# Altium schematic sheet CentralUnit_Schematic.SchDoc(CentralUnit_Schematic)
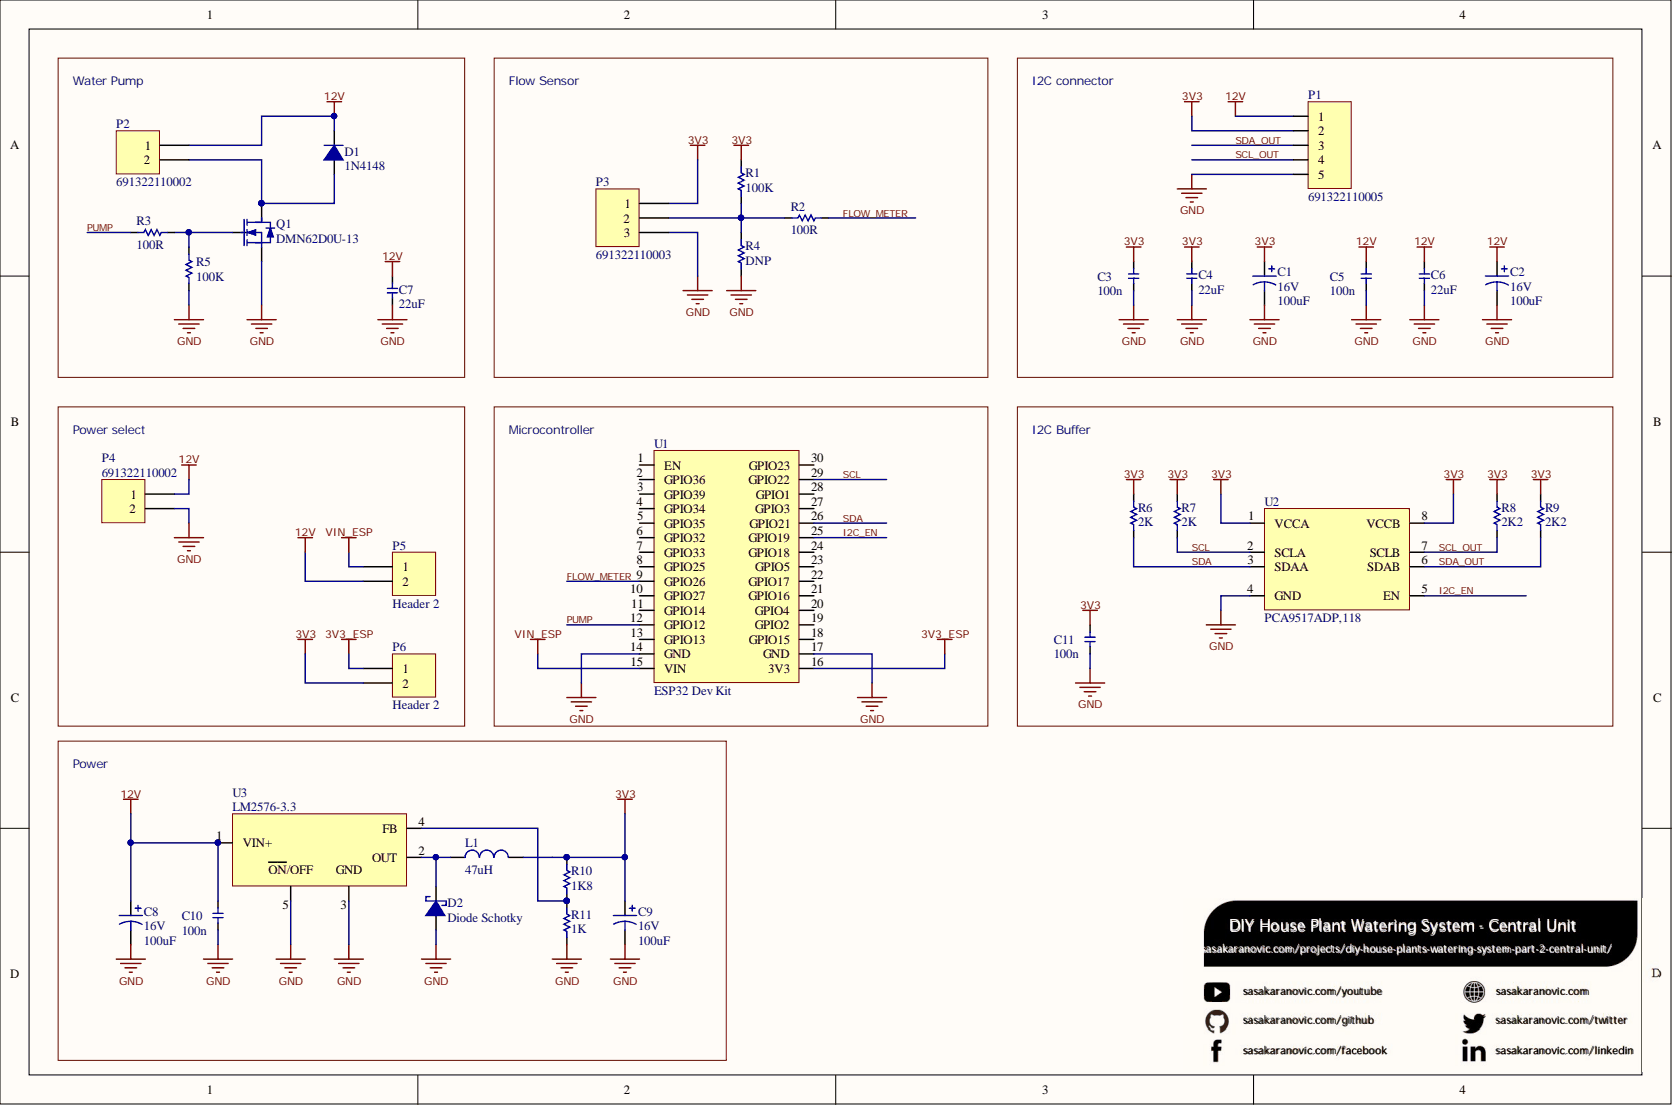
[diagram p0: CentralUnit_Schematic.SchDoc]

## Parts
C1  16V  value 100uF  [Electrolytic Cap 2.54mm]
C2  16V  value 100uF  [Electrolytic Cap 2.54mm]
C3  value 100n  [0603 - CAP HS]  (hidden comment: Cap)
C4  value 22uF  [0603 - CAP HS]  (hidden comment: Cap)
C5  value 100n  [0603 - CAP HS]  (hidden comment: Cap)
C6  value 22uF  [0603 - CAP HS]  (hidden comment: Cap)
C7  value 22uF  [0603 - CAP HS]  (hidden comment: Cap)
C8  16V  value 100uF  [Electrolytic Cap 2.54mm]
C9  16V  value 100uF  [Electrolytic Cap 2.54mm]
C10  value 100n  [0603 - CAP HS]  (hidden comment: Cap)
C11  value 100n  [0603 - CAP HS]  (hidden comment: Cap)
D1  1N4148  [SOD123F]
D2  Diode Schotky  [1206 Polarized]
L1  value 47uH  [IND_TH_SMD]  (hidden comment: Inductor)
P1  691322110005  [TERMINAL_5POS_90DEG_2PART]
P2  691322110002  [TERMINAL_2POS_90DEG_2PART]
P3  691322110003  [TERMINAL_3POS_90DEG_2PART]
P4  691322110002  [TERMINAL_2POS_90DEG_2PART]
P5  Header 2  [Header 2x1]
P6  Header 2  [Header 2x1]
Q1  DMN62D0U-13  [SOT90P240X100-3N]
R1  value 100K  [0603 - HS]  (hidden comment: Res)
R2  value 100R  [0603 - HS]  (hidden comment: Res)
R3  value 100R  [0603 - HS]  (hidden comment: Res)
R4  value DNP  [0603 - HS]  (hidden comment: Res)  (no BOM)
R5  value 100K  [0603 - HS]  (hidden comment: Res)
R6  value 2K  [0603 - HS]  (hidden comment: Res)
R7  value 2K  [0603 - HS]  (hidden comment: Res)
R8  value 2K2  [0603 - HS]  (hidden comment: Res)
R9  value 2K2  [0603 - HS]  (hidden comment: Res)
R10  value 1K8  [0603 - HS]  (hidden comment: Res)
R11  value 1K  [0603 - HS]  (hidden comment: Res)
U1  ESP32 Dev Kit  [ESP32-DevModule]
U2  PCA9517ADP,118  [MSOP8-3mm]
U3  LM2576-3.3  [TO263-5]

## Nets
3V3: C1.1 C3.2 C4.2 C9.1 C11.2 L1.2 P1.2 P3.1 P6.2 R1.2 R6.2 R7.2 R8.2 R9.2 R10.1 U2.1(VCCA) U2.8(VCCB)
3V3_ESP: P6.1 U1.16(3V3)
12V: C2.1 C5.2 C6.2 C7.2 C8.1 C10.2 D1.K P1.1 P2.1 P4.1 P5.2 U3.1(VIN+)
GND: C1.2 C2.2 C3.1 C4.1 C5.1 C6.1 C7.1 C8.2 C9.2 C10.1 C11.1 D2.A P1.5 P3.3 P4.2 Q1.2 R4.1 R5.1 R11.2 U1.14(GND) U1.17(GND) U2.4(GND) U3.3 U3.5
VIN_ESP: P5.1 U1.15(VIN)
FLOW_METER: R2.2 U1.9(GPIO26)
I2C_EN: U1.25(GPIO19) U2.5(EN)
PUMP: R3.1 U1.12(GPIO12)
SCL: R7.1 U1.29(GPIO22) U2.2(SCLA)
SCL_OUT: P1.4 R8.1 U2.7(SCLB)
SDA: R6.1 U1.26(GPIO21) U2.3(SDAA)
SDA_OUT: P1.3 R9.1 U2.6(SDAB)
NetD1_A: D1.A P2.2 Q1.3
NetD2_K: D2.K L1.1 U3.2(OUT)
NetP3_2: P3.2 R1.1 R2.1 R4.2
NetQ1_1: Q1.1 R3.2 R5.2
NetR10_2: R10.2 R11.1 U3.4(FB)

Not connected: U1 1(EN) 2(GPIO36) 3(GPIO39) 4(GPIO34) 5(GPIO35) 6(GPIO32) 7(GPIO33) 8(GPIO25) 10(GPIO27) 11(GPIO14) 13(GPIO13) 18(GPIO15) 19(GPIO2) 20(GPIO4) 21(GPIO16) 22(GPIO17) 23(GPIO5) 24(GPIO18) 27(GPIO3) 28(GPIO1) 30(GPIO23)

## Library data of the parts
C1 C2 C8 C9 = Cap Electrolytic: Polarized Capacitor (Axial); Manufacturer Part Number: UKT1V101MED1TD; Manufacturer: Nichicon; Library Name: Schematic_Misc.SchLib; Distributor Part Number: 493-10673-1-ND; Distributor: DigiKey; Component Kind: Standard
C3 C5 C10 C11 = Cap: CAP CER 0.1UF 50V X7R 0603; Manufacturer Part Number: CL10B104KB8NNNL; Manufacturer: Samsung Electro-Mechanics; Simulation: CAP; Signal Integrity: Cap; Distributor Part Number: 1276-CL10B104KB8NNNLCT-ND; Distributor: DigiKey; Component Kind: Standard; Library Name: Moji Schematici.SchLib
C4 C6 C7 = Cap: Capacitor; Manufacturer Part Number: UVZ1J220MDD1TD; Manufacturer: Nichicon; Simulation: CAP; Signal Integrity: Cap; Distributor Part Number: 493-13481-1-ND; Distributor: DigiKey; Component Kind: Standard; Library Name: Moji Schematici.SchLib
D1 = Diode: DIODE GEN PURP 100V 300MA SOD123; Manufacturer Part Number: 1N4148W-7-F; Manufacturer: Diodes Incorporated; Distributor Part Number: 1N4148W-FDICT-ND; Distributor: DigiKey; Component Kind: Standard; Library Name: Moji Schematici (1).SchLib
D2 = Diode Schotky: Manufacturer Part Number: SD1206S040S1R0; Manufacturer: AVX Corporation; Library Name: Schematic_Misc.SchLib; Distributor Part Number: 478-7805-1-ND; Distributor: DigiKey; Component Kind: Standard
L1 = Inductor: Shielded - Inductor 200mOhm Nonstandard; Manufacturer Part Number: TYS6045470M-10; Manufacturer: Laird-Signal Integrity Products; Simulation: INDUCTOR; Signal Integrity: Inductor; Library Name: Schematic_Misc.SchLib; Distributor Part Number: 240-2745-2-ND; Distributor: DigiKey; Component Kind: Standard
P1 = Terminal 5_691322110005: TERM BLOCK HDR 5POS 90DEG 3.5MM; Manufacturer: Würth Elektronik; Manufacturer Part Number: 691322110005; Library Name: Schematic_Misc.SchLib; Distributor Part Number: 732-2772-ND; Distributor: DigiKey; Component Kind: Standard
P2 P4 = Terminal 2_691322110002: 2 Position Terminal Block Header, Male Pins, Shrouded (4 Side) 0.138" (3.50mm) 90°, Right Angle - Through Hole; Manufacturer: Würth Elektronik; Manufacturer Part Number: 691322110002; Library Name: Schematic_Misc.SchLib; Distributor Part Number: 732-2769-ND; Distributor: DigiKey; Component Kind: Standard
P3 = Terminal 3_691322110003_1: TERM BLOCK HDR 3POS 90DEG 3.5MM; Manufacturer: Würth Elektronik; Manufacturer Part Number: 691322110003; Library Name: Schematic_Misc.SchLib; Distributor Part Number: 732-2770-ND; Distributor: DigiKey; Component Kind: Standard
P5 P6 = Header 2: Header, 2-Pin; Manufacturer Part Number: M20-9960245; Manufacturer: Harwin Inc.; Library Name: Schematic_Misc.SchLib; Distributor Part Number: 952-2244-ND; Distributor: DigiKey; Component Kind: Standard
Q1 = DMN62D0U-13: MOSFET N-CH 60V 0.38A; Manufacturer: Diodes Incorporated; Manufacturer Part Number: DMN62D0U-13; Library Name: Schematic_Misc.SchLib; Distributor Part Number: DMN62D0U-13DICT-ND; Distributor: DigiKey; Component Kind: Standard
R1-R4 R6-R9 = Res: RES SMD 0 OHM JUMPER 1/10W 0603; Manufacturer Part Number: AC0603JR-070RL; Manufacturer: Yageo; Distributor Part Number: 311-0.0LECT-ND; Distributor: DigiKey; Library Name: Moji Schematici.SchLib
  R1-R3 R6-R9: Component Kind: Standard
R5 = Res: RES SMD 1M OHM 5% 1/10W 0603; Manufacturer Part Number: RC0603JR-071ML; Manufacturer: Yageo; Distributor Part Number: 311-1.0MGRCT-ND; Distributor: DigiKey; Component Kind: Standard; Library Name: Moji Schematici.SchLib
R10 = Res: Resistor; Manufacturer Part Number: RC0603JR-071K8L; Manufacturer: Yageo; Library Name: Schematic_Misc.SchLib; Distributor Part Number: 311-1.8KGRCT-ND; Distributor: DigiKey; Component Kind: Standard
R11 = Res: Resistor; Manufacturer Part Number: RC0603JR-071KL; Manufacturer: Yageo; Library Name: Schematic_Misc.SchLib; Distributor Part Number: 311-1.0KGRCT-ND; Distributor: DigiKey; Component Kind: Standard
U1 = ESP32_DEV: Manufacturer Part Number: ESP32-Dev Module; Manufacturer: Espressif; Library Name: Schematic_Misc.SchLib; Distributor Part Number: TBD; Distributor: TBD; Component Kind: Standard
U2 = PCA9517ADP,118: IC REDRIVER I2C 1CH 8TSSOP; Manufacturer: NXP USA Inc.; Manufacturer Part Number: PCA9517ADP,118; Library Name: Schematic_Misc.SchLib; Distributor Part Number: 568-5926-1-ND; Distributor: DigiKey; Component Kind: Standard
U3 = LM2576-xx: Buck Switching Regulator IC Positive Fixed 3.3V 1 Output 3A TO-263-6, D²Pak (5 Leads + Tab), TO-263BA; Manufacturer Part Number: LM2596S-3.3/NOPB; Manufacturer: Texas Instruments; Library Name: Schematic_Misc.SchLib; Distributor Part Number: LM2596S-3.3/NOPB-ND; Distributor: DigiKey; Component Kind: Standard
